# Altium schematic sheet CC2640R2F巴伦.SchDoc(CC2640R2F巴伦)
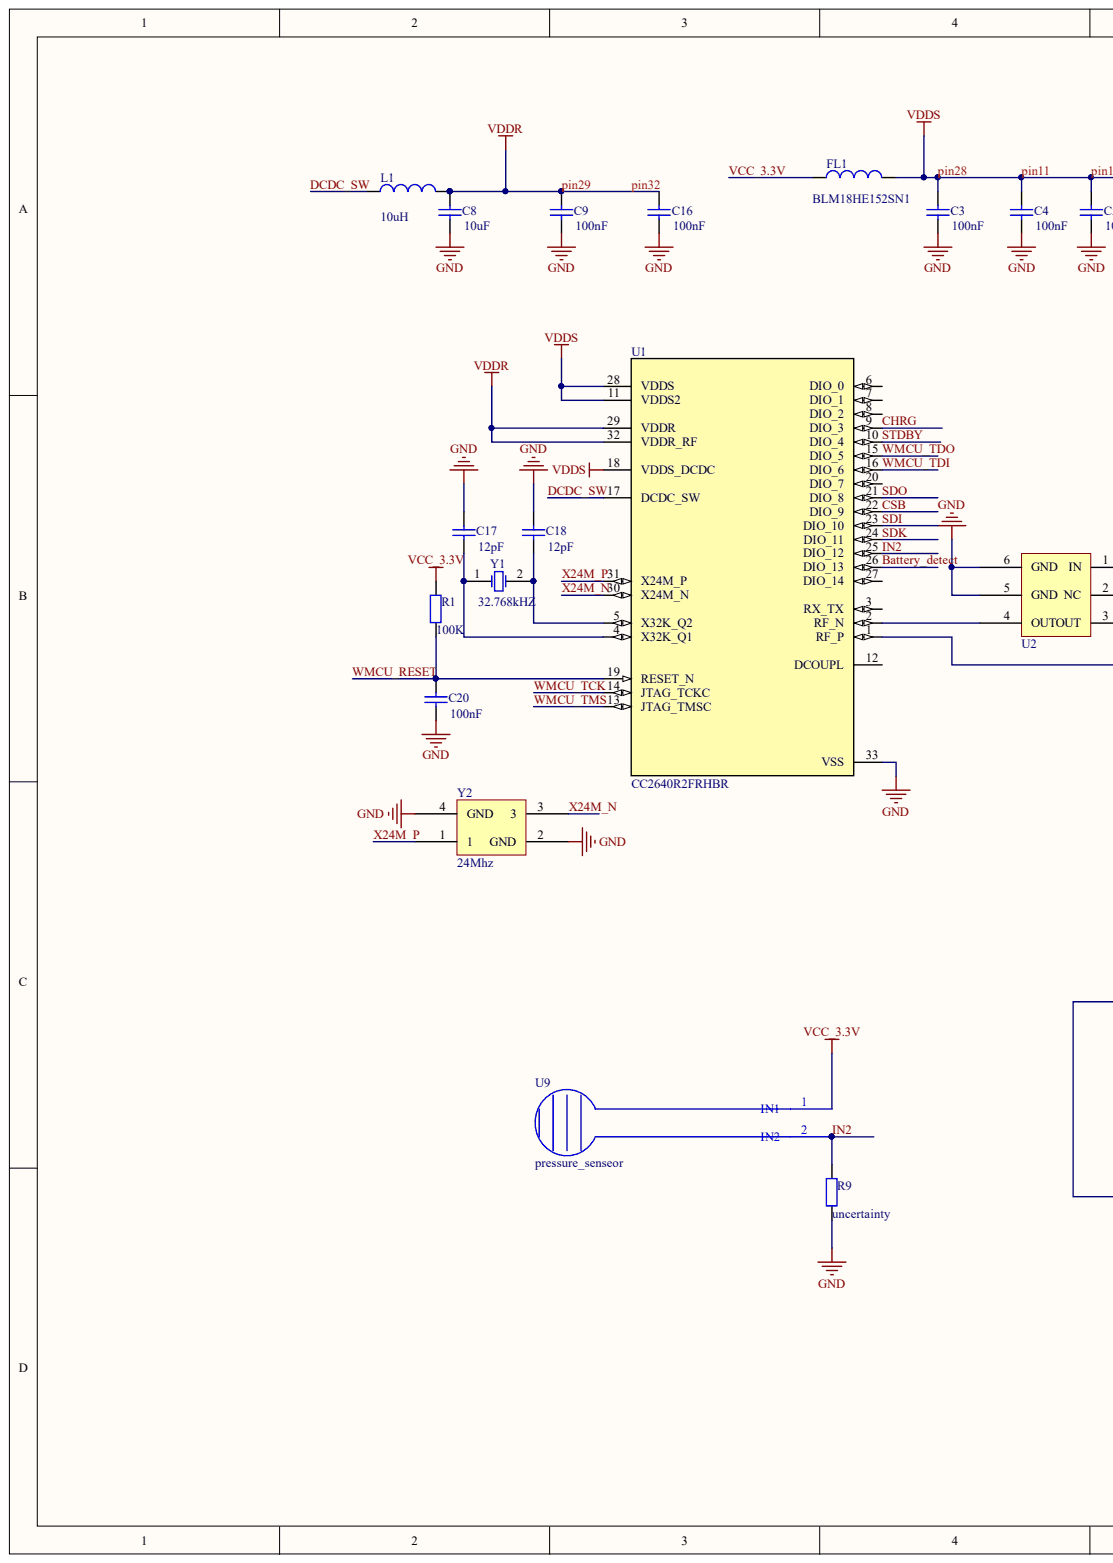
[diagram p0: CC2640R2F巴伦.SchDoc, left]
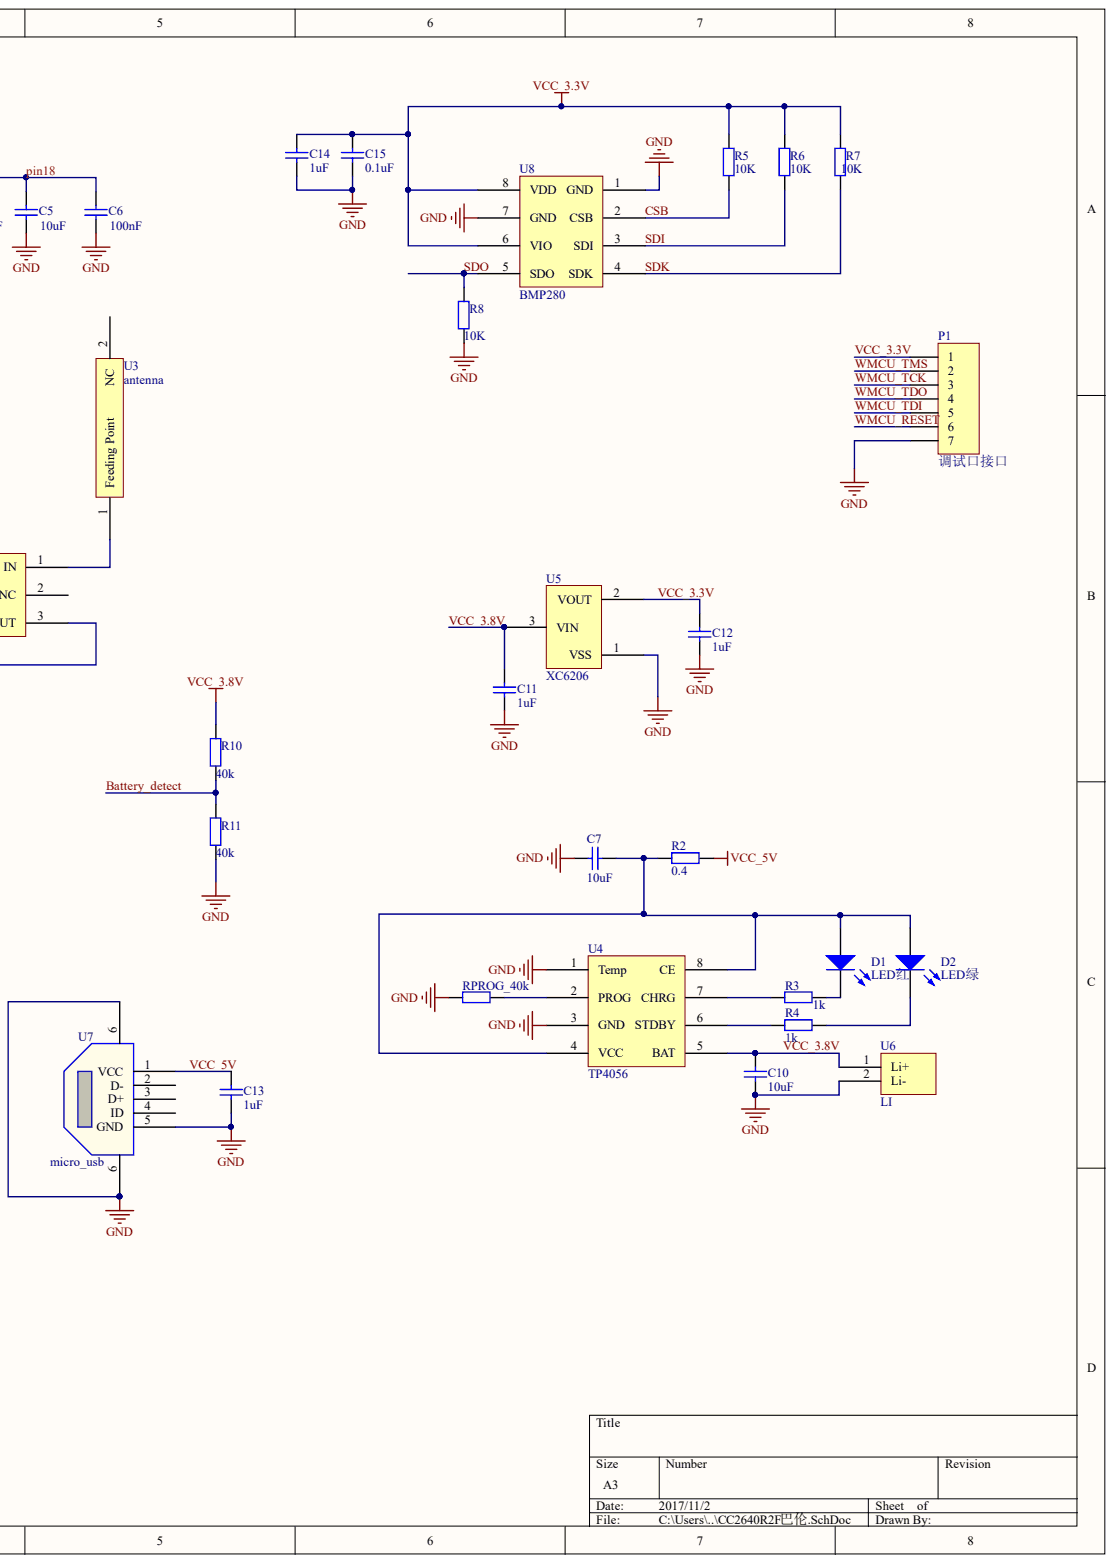
[diagram p1: CC2640R2F巴伦.SchDoc, right]

## Parts
C3  value 100nF  [0402-xin]  (hidden comment: Cap)
C4  value 100nF  [0402-xin]  (hidden comment: Cap)
C5  value 10uF  [0402-xin]  (hidden comment: Cap)
C6  value 100nF  [0402-xin]  (hidden comment: Cap)
C7  value 10uF  [0402-xin]  (hidden comment: Cap)
C8  value 10uF  [0402-xin]  (hidden comment: Cap)
C9  value 100nF  [0402-xin]  (hidden comment: Cap)
C10  value 10uF  [0402-xin]  (hidden comment: Cap)
C11  value 1uF  [0402-xin]  (hidden comment: Cap)
C12  value 1uF  [0402-xin]  (hidden comment: Cap)
C13  value 1uF  [0402-xin]  (hidden comment: Cap)
C14  value 1uF  [0402-xin]  (hidden comment: Cap)
C15  value 0.1uF  [0402-xin]  (hidden comment: Cap)
C16  value 100nF  [0402-xin]  (hidden comment: Cap)
C17  value 12pF  [0402-xin]  (hidden comment: Cap)
C18  value 12pF  [0402-xin]  (hidden comment: Cap)
C20  value 100nF  [0402-xin]  (hidden comment: Cap)
D1  LED红  [0603-xin]
D2  LED绿  [0603-xin]
FL1  value BLM18HE152SN1  [0603-xin]  (hidden comment: Inductor)
L1  value 10uH  [0805-xin]  (hidden comment: Inductor)
P1  调试口接口  [HEADER7]
R1  value 100K  [0402-xin]  (hidden comment: Res2)
R2  value 0.4  [0402-xin]  (hidden comment: Res2)
R3  value 1k  [0402-xin]  (hidden comment: Res2)
R4  value 1k  [0402-xin]  (hidden comment: Res2)
R5  value 10K  [0402-xin]  (hidden comment: Res2)
R6  value 10K  [0402-xin]  (hidden comment: Res2)
R7  value 10K  [0402-xin]  (hidden comment: Res2)
R8  value 10K  [0402-xin]  (hidden comment: Res2)
R9  value uncertainty  [0402-xin]  (hidden comment: Res2)
R10  value 40k  [0402-xin]  (hidden comment: Res2)
R11  value 40k  [0402-xin]  (hidden comment: Res2)
RPROG_40k  Res2  [0805-xin]  (value not drawn)
U1  CC2640R2FRHBR  [RHB0032E]
U2  2450BM14G0011  [2450BM14G0011]  (value not drawn)
U3  antenna  [antenna]
U4  TP4056  [SO-8]
U5  XC6206  [SOT-23]
U6  LI  [header2]
U7  micro_usb  [MICRO-USB]
U8  BMP280  [BMP280]
U9  pressure_senseor  [header2]
Y1  32.768kHZ  [32.768khz]
Y2  24Mhz  [24MHZ]

## Nets
GND: C3.1 C4.1 C5.1 C6.1 C7.2 C8.1 C9.1 C10.2 C11.2 C12.2 C13.2 C14.2 C15.2 C16.1 C17.2 C18.2 C20.1 P1.7 R8.2 R9.2 R11.2 RPROG_40k.2 U1.33(VSS) U2.5(GND) U2.6(GND) U4.1(Temp) U4.3(GND) U5.1 U6.2 U7.5(GND) U7.6 U8.1(GND) U8.7(GND) Y2.2(GND) Y2.4(GND)
VDDR: C8.2 C9.2 C16.2 L1.2 U1.29(VDDR) U1.32(VDDR_RF)
VDDS: C3.2 C4.2 C5.2 C6.2 FL1.2 U1.11(VDDS2) U1.18(VDDS_DCDC) U1.28(VDDS)
BATTERY_DETECT: R10.2 R11.1 U1.26(DIO_13)
CHRG: U1.9(DIO_3)
CSB: R5.2 U1.22(DIO_9) U8.2(CSB)
DCDC_SW: L1.1 U1.17(DCDC_SW)
IN2: R9.1 U1.25(DIO_12) U9.2
SDI: R6.2 U1.23(DIO_10) U8.3(SDI)
SDK: R7.2 U1.24(DIO_11) U8.4(SDK)
SDO: R8.1 U1.21(DIO_8) U8.5(SDO)
STDBY: U1.10(DIO_4)
VCC_3.3V: C12.1 C14.1 C15.1 FL1.1 P1.1 R1.2 R5.1 R6.1 R7.1 U5.2 U8.6(VIO) U8.8(VDD) U9.1
VCC_3.8V: C10.1 C11.1 R10.1 U4.5(BAT) U5.3 U6.1
VCC_5V: C13.1 R2.1 U7.1(VCC)
WMCU_RESET: C20.2 P1.6 R1.1 U1.19(RESET_N)
WMCU_TCK: P1.3 U1.14(JTAG_TCKC)
WMCU_TDI: P1.5 U1.16(DIO_6)
WMCU_TDO: P1.4 U1.15(DIO_5)
WMCU_TMS: P1.2 U1.13(JTAG_TMSC)
X24M_N: U1.30(X24M_N) Y2.3
X24M_P: U1.31(X24M_P) Y2.1
NetC7_1: C7.1 D1.1 D2.1 R2.2 U4.4(VCC) U4.8(CE)
NetC17_1: C17.1 U1.4(X32K_Q1) Y1.1
NetC18_1: C18.1 U1.5(X32K_Q2) Y1.2
NetD1_2: D1.2 R3.1
NetD2_2: D2.2 R4.1
NetR3_2: R3.2 U4.7(CHRG)
NetR4_2: R4.2 U4.6(STDBY)
NetRPROG_40k_1: RPROG_40k.1 U4.2(PROG)
NetU1_1: U1.1(RF_P) U2.3(OUT)
NetU1_2: U1.2(RF_N) U2.4(OUT)
NetU2_1: U2.1(IN) U3.1

Not connected: U1 3(RX_TX) 6(DIO_0) 7(DIO_1) 8(DIO_2) 12(DCOUPL) 20(DIO_7) 27(DIO_14); U2 2(NC); U3 2; U7 2(D-) 3(D+) 4(ID)

## Library data of the parts
C3-C18 C20 = Cap: Capacitor; Component Kind: Standard; LatestRevisionDate: 17-Jul-2002; LatestRevisionNote: Re-released for DXP Platform.; Library Name: Miscellaneous Devices.IntLib; PackageDescription: Radial Cap, Thru-Hole; 2 Leads; 0.3 in Pin Spacing; PackageReference: RAD-0.3; Published: 8-Jun-2000; Signal Integrity: Cap; Simulation: CAP; Publisher: Altium Limited
D1 D2 = LED3: Typical BLUE SiC LED; Component Kind: Standard; DatasheetVersion: 16-Apr-2002; LatestRevisionDate: 17-Dec-2003; LatestRevisionNote: PCB Footprint replaced.; Library Name: Miscellaneous Devices.IntLib; PackageReference: 3.5X2.8X1.9; PackageVersion: 16-Apr-2002; Published: 8-Jun-2000; Signal Integrity: LED; Simulation: LED3; Publisher: Altium Limited
FL1 L1 = Inductor: Code_IEC: 1005; Code_JEITA: 0402; Component Kind: Standard; Description: Inductor; LatestRevisionDate: 29-May-2009; LatestRevisionNote: IPC-7351 Footprint Added.; Library Name: Miscellaneous Devices.IntLib; PackageDescription: Chip Inductor; PackageReference: 0402-A; Published: 8-Jun-2000; Signal Integrity: Inductor; Simulation: INDUCTOR; Publisher: Altium Limited
P1 = Header 7: Header, 7-Pin; Component Kind: Standard; LatestRevisionDate: 17-Jul-2002; LatestRevisionNote: Re-released for DXP Platform.; Library Name: Miscellaneous Connectors.IntLib; Signal Integrity: Connector; Publisher: Altium Limited
R1-R11 RPROG_40k = Res2: Resistor; Component Kind: Standard; LatestRevisionDate: 17-Jul-2002; LatestRevisionNote: Re-released for DXP Platform.; Library Name: Miscellaneous Devices.IntLib; PackageDescription: Axial Device, Thru-Hole; 2 Leads; 0.4 in Pin Spacing; PackageReference: AXIAL-0.4; Published: 8-Jun-2000; Signal Integrity: Res; Simulation: RESISTOR; Publisher: Altium Limited
U1 = CC2640R2FRHBR: Imported; Manufacturer: Texas Instruments; Component Kind: Standard; Library Name: 2017-10-29_19-45-12.SchLib; PartNumber: CC2640R2FRHBR; RefDes: RefDes; TYPE: TYPE; Value: Value
Y1 = XTAL: Crystal Oscillator; Component Kind: Standard; LatestRevisionDate: 17-Jul-2002; LatestRevisionNote: Re-released for DXP Platform.; Library Name: Miscellaneous Devices.IntLib; PackageReference: R38; Published: 8-Jun-2000; Simulation: XTAL; Publisher: Altium Limited
U2 = 2450BM14G0011: Component Kind: Standard; Library Name: BM14G0011.SchLib
U3 = antenna: Component Kind: Standard; Library Name: BM14G0011.SchLib
U4 = TP4056: Component Kind: Standard; Library Name: BM14G0011.SchLib
U5 = XC6206: Component Kind: Standard; Library Name: BM14G0011.SchLib
U6 = LI: Component Kind: Standard; Library Name: BM14G0011.SchLib
U7 = micro_usb: Component Kind: Standard; Library Name: BM14G0011.SchLib
U8 = BMP280: Component Kind: Standard; Library Name: BM14G0011.SchLib
U9 = pressure_senseor: Component Kind: Standard; Library Name: BM14G0011.SchLib
Y2 = 24Mhz: Component Kind: Standard; Library Name: BM14G0011.SchLib
